# Altium schematic sheet Power.SchDoc
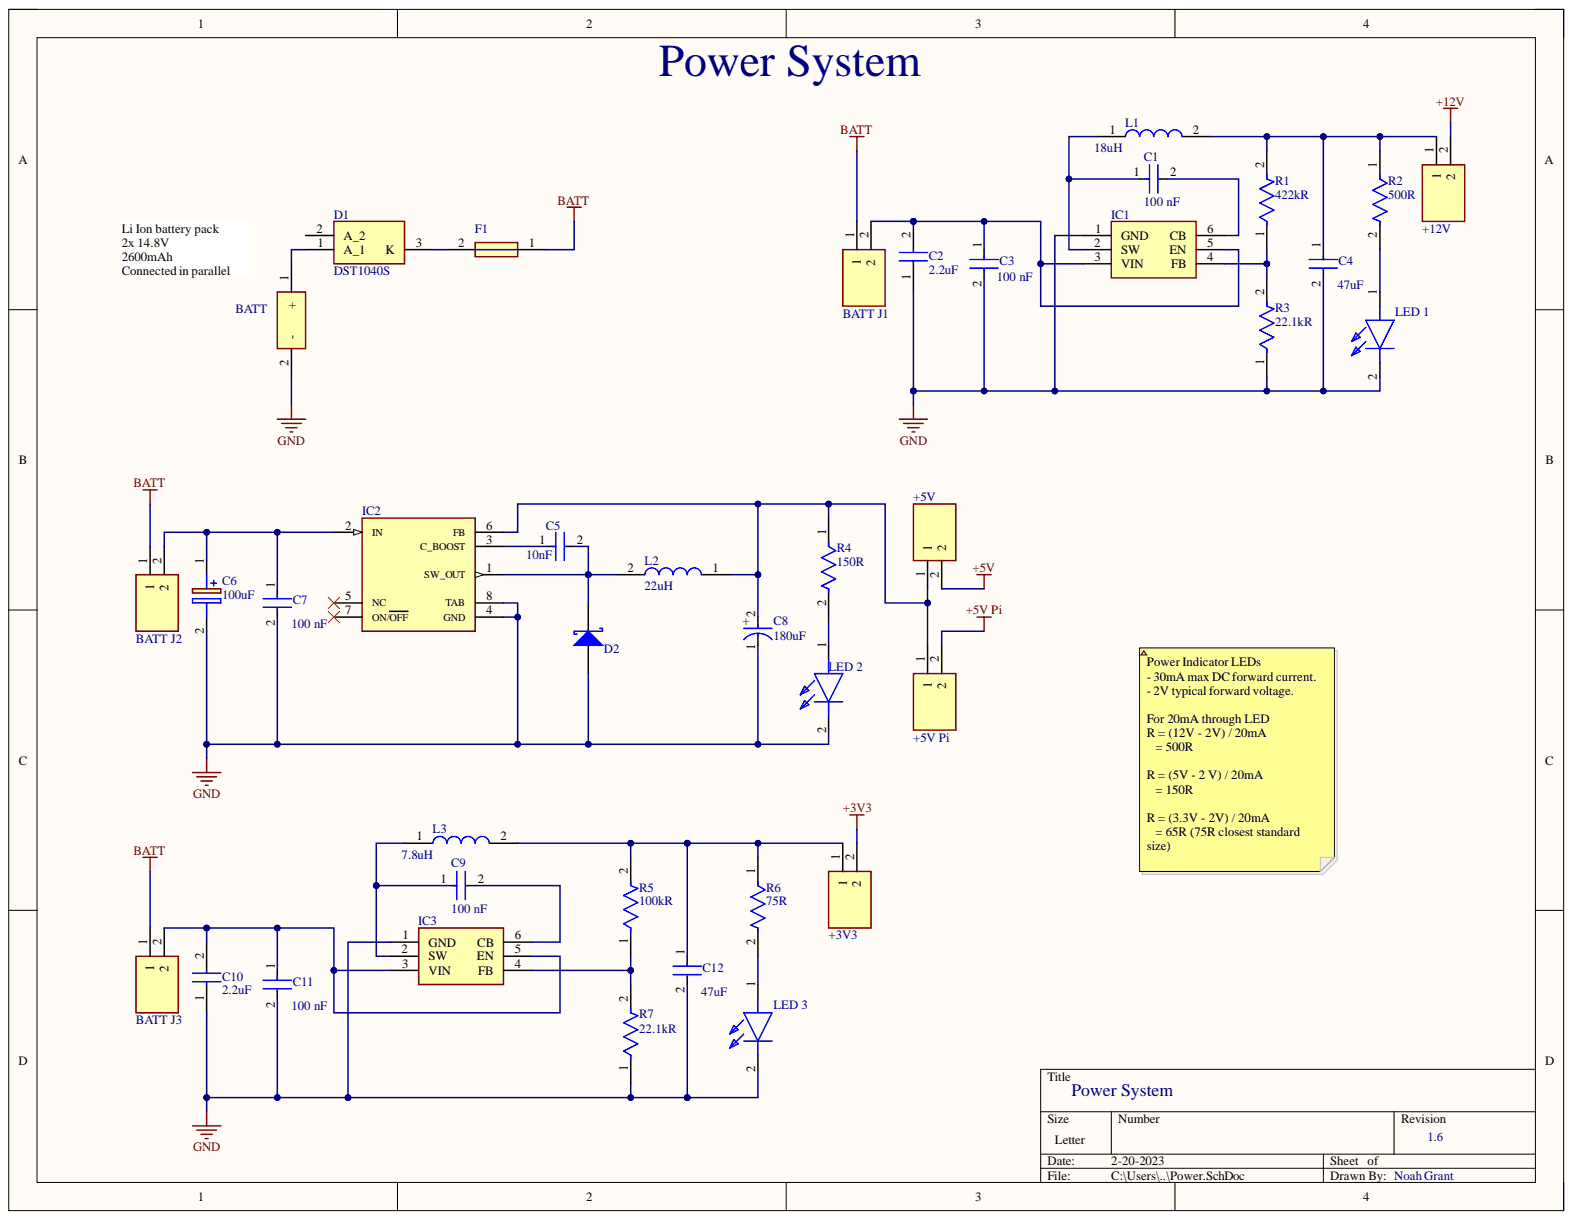
[diagram p0: Power.SchDoc]

## Parts
+3V3  0022284023  [HDRV2W87P0X254_1X2_508X249X863P]  (value not drawn)
+5V  0022284023  [HDRV2W87P0X254_1X2_508X249X863P]  (value not drawn)
+5V_Pi  0022284023  [HDRV2W87P0X254_1X2_508X249X863P]  (value not drawn)
+12V  0022284023  [HDRV2W87P0X254_1X2_508X249X863P]  (value not drawn)
BATT  1702473  [1702473]  (value not drawn)
BATT_J1  0022284023  [HDRV2W87P0X254_1X2_508X249X863P]  (value not drawn)
BATT_J2  0022284023  [HDRV2W87P0X254_1X2_508X249X863P]  (value not drawn)
BATT_J3  0022284023  [HDRV2W87P0X254_1X2_508X249X863P]  (value not drawn)
C1  value 100 nF  Capacitance: 100 nF  [FP-CC0603-DA-MFG]  (hidden comment: CC0603KPX7R9BB104)
C2  Capacitance: 2.2uF  [CAPC3216X180N]  (hidden comment: GRM31CR61H225KA88L)
C3  value 100 nF  Capacitance: 100 nF  [FP-CC0603-DA-MFG]  (hidden comment: CC0603KPX7R9BB104)
C4  Capacitance: 47uF  [FP-GRM32E-0_3-0_2-IPC_C]  (hidden comment: GRM32ER61C476KE15L)
C5  Capacitance: 10nF  [FP-CGA4J-IPC_B]  (hidden comment: CGA4C2C0G1H103J060AA)
C6  Capacitance: 100uF  [CAPAE1030X1050N]  (hidden comment: 50PFV100M10X10.5)
C7  value 100 nF  [FP-CC0603-DA-MFG]  (hidden comment: CC0603KPX7R9BB104)
C8  Capacitance: 180uF  [FP-OS-CON-SMT-C6-MFG]  (hidden comment: 16SVPF180M)
C9  value 100 nF  Capacitance: 100 nF  [FP-CC0603-DA-MFG]  (hidden comment: CC0603KPX7R9BB104)
C10  Capacitance: 2.2uF  [CAPC3216X180N]  (hidden comment: GRM31CR61H225KA88L)
C11  value 100 nF  Capacitance: 100 nF  [FP-CC0603-DA-MFG]  (hidden comment: CC0603KPX7R9BB104)
C12  Capacitance: 47uF  [FP-GRM32E-0_3-0_2-IPC_C]  (hidden comment: GRM32ER61C476KE15L)
D1  DST1040S  [DST1040SA]
D2  B560C-13-F  [DIOD-B5X0C-2_M]  (value not drawn)
F1  TR2_6125FF5-R  [FUSC8640X287N]  (value not drawn)
IC1  LMR51420XDDCR  [SOT95P280X110-6N]  (value not drawn)
IC2  LM2677SX-5.0/NOPB  [TO127P1435X464-8N]  (value not drawn)
IC3  LMR51420XDDCR  [SOT95P280X110-6N]  (value not drawn)
L1  Inductance: 18uH  [SRR1210]  (hidden comment: SRR1210-180M)
L2  Inductance: 22uH  [INDPM132125X500N]  (hidden comment: MPX1D1250L220)
L3  Inductance: 7.8uH  [WE-HCI_103023]  (hidden comment: 744325780)
LED_1  APL3015EC-F01  [APL3015ECF01]  (value not drawn)
LED_2  APL3015EC-F01  [APL3015ECF01]  (value not drawn)
LED_3  APL3015EC-F01  [APL3015ECF01]  (value not drawn)
R1  Resistance: 422kR  [FP-ERA6A-IPC_A]  (hidden comment: ERA-6AEB4223V)
R2  500R  [FP-TFCR0603-IPC_A]
R3  Resistance: 22.1kR  [FP-RNCP0805-IPC_C]  (hidden comment: RNCP0805FTD22K1)
R4  150R  [FP-MCT0603-IPC_C]
R5  Resistance: 100kR  [FP-RC0603-0_55-MFG]  (hidden comment: RC0603FR-07100KL)
R6  75R  [FP-RT0603-MFG]
R7  Resistance: 22.1kR  [FP-RNCP0805-IPC_C]  (hidden comment: RNCP0805FTD22K1)

## Nets
+3V3: +3V3.2
+5V: +5V.2
+5V Pi: +5V_Pi.2
+12V: +12V.2
BATT: BATT_J1.1 BATT_J2.1 BATT_J3.1 F1.1
GND: BATT.2 C2.1 C3.2 C4.2 C6.2 C7.2 C8.1 C10.1 C11.2 C12.2 D2.2 IC1.1(GND) IC2.4(GND) IC2.8(TAB) IC3.1(GND) LED_1.2 LED_2.2 LED_3.2 R3.1 R7.1
Net+3V3_1: +3V3.1 C12.1 L3.2 R5.2 R6.1
Net+5V Pi_1: +5V.1 +5V_Pi.1 C8.2 IC2.6(FB) L2.1 R4.1
Net+12V_1: +12V.1 C4.1 L1.2 R1.2 R2.1
NetBATT J1_2: BATT_J1.2 C2.2 C3.1 IC1.3(VIN) IC1.5(EN)
NetBATT J2_2: BATT_J2.2 C6.1 C7.1 IC2.2(IN)
NetBATT J3_2: BATT_J3.2 C10.2 C11.1 IC3.3(VIN) IC3.5(EN)
NetBATT_1: BATT.1 D1.1
NetC1_1: C1.1 IC1.2(SW) L1.1
NetC1_2: C1.2 IC1.6(CB)
NetC5_1: C5.1 IC2.3(C_BOOST)
NetC5_2: C5.2 D2.1 IC2.1(SW_OUT) L2.2
NetC9_1: C9.1 IC3.2(SW) L3.1
NetC9_2: C9.2 IC3.6(CB)
NetD1_3: D1.3 F1.2
NetIC1_4: IC1.4(FB) R1.1 R3.2
NetIC3_4: IC3.4(FB) R5.1 R7.2
NetLED 1_1: LED_1.1 R2.2
NetLED 2_1: LED_2.1 R4.2
NetLED 3_1: LED_3.1 R6.2

Not connected: D1 2; IC2 5(NC) 7(ON/OFF)

## Library data of the parts
+3V3 +5V +5V_Pi +12V BATT_J1-BATT_J3 = 0022284023: Connector; Manufacturer_Name: Molex; Arrow Part Number: 0022284023; Arrow Price/Stock: https://www.arrow.com/en/products/0022284023/molex; Component Kind: Standard; Datasheet Link: https://www.molex.com/pdm_docs/sd/022284023_sd.pdf; Height: 8.63mm; Manufacturer_Part_Number: 0022284023; Mouser Part Number: N/A; Mouser Price/Stock: https://www.mouser.co.uk/ProductDetail/Molex/0022284023?qs=PBcRNPEHKsr%2FPE%2F%252B8ije0g%3D%3D
BATT = 1702473: Connector; Manufacturer_Name: Phoenix Contact; Arrow Part Number: 1702473; Arrow Price/Stock: https://www.arrow.com/en/products/1702473/phoenix-contact?region=nac; Component Kind: Standard; Datasheet Link: http://www.phoenixcontact.com/de/produkte/1702473/pdf; Height: 5.22mm; Manufacturer_Part_Number: 1702473; Mouser Part Number: 651-1702473; Mouser Price/Stock: https://www.mouser.co.uk/ProductDetail/Phoenix-Contact/1702473?qs=i0qWf6NAoDu6Kp2LncOlag%3D%3D
C1 C3 C9 C11 = CMP-03422-000468-1: CAP CER 0.1UF 50V X7R 0603; Manufacturer: YAGEO; Material: Ceramic; Temperature Coefficient: 15%; Tolerance: 0.1; Automotive: No; Category: Cap; Component Kind: Standard; ComponentLink2Description: Datasheet; ComponentLink2URL: http://www.yageo.com/documents/recent/UPY-GPHC_X7R_6.3V-to-50V_18.pdf; Device Class L1: Passive Components; Device Class L2: Capacitors; Device Class L3: Ceramic Capacitors; Digikey Description: CAP CER 0.1UF 50V X7R 0603; Footprint Url: http://www.yageo.com/exep/pages/download/literatures/UPY-C_GEN_24.pdf; Height: 0.9mm; Lead Free: Yes; Library Name: Altium Content Vault; Manufacturer Part Number: CC0603KPX7R9BB104; Mouser Description: Multilayer Ceramic Capacitors MLCC - SMD/SMT 100nF 50V X7R 10%; Octopart: https://octopart.com/cc0603kpx7r9bb104-yageo-12885113; Package: CC0603-DA; RoHS: TRUE; Temperature: -55°C to +125°C; Temperature Characteristic: X7R; Temperature Range High: +125°C; Temperature Range Low: -55°C; Voltage: 50 V; Voltage Rating: 50 V
C2 C10 = GRM31CR61H225KA88L: Capacitor; Manufacturer_Name: Murata Electronics; Component Kind: Standard; Datasheet Link: https://datasheet.datasheetarchive.com/originals/distributors/Datasheets_SAMA/ec4f1577dddcc7a13ddad897a28002d3.pdf; Height: 1.8mm; Manufacturer_Part_Number: GRM31CR61H225KA88L; Mouser Part Number: 81-GRM31CR61H225KA8L; Mouser Price/Stock: https://www.mouser.co.uk/ProductDetail/Murata-Electronics/GRM31CR61H225KA88L?qs=PNuoBeNXpiW6XsFe%2FHBnHw%3D%3D
C4 C12 = CMP-2000-06362-2: CAP CER 47UF 16V X5R 1210; Material: Ceramic; Tolerance: 10%; Case Code (Imperial): 1210; Case Code (Metric): 3225; Case/Package: 1210; Component Kind: Standard; Depth: 2.5mm; Dielectric: X5R; Height: 2.5mm; Lead Free: Lead Free; Length: 3.2mm; Library Name: Altium Content Vault; Max Operating Temperature: 85°C; Min Operating Temperature: -55°C; Mount: Surface Mount; Number of Pins: 2; Package Quantity: 1; Packaging: Cut Tape; Radiation Hardening: No; REACH SVHC: No SVHC; RoHS Compliant: Yes; Termination: SMD/SMT; Thickness: 0.106inch; Voltage: 16V; Voltage Rating: 16V; Voltage Rating (DC): 16V; Width: 0.098inch
C5 = CMP-08246-000595-1: Cap Ceramic 10nF 50V C0G 5% Pad SMD 0805 +125°C Automotive T/R; Case Code (Imperial): 0805; Case Code (Metric): 2012; Case/Package: 0805; Component Kind: Standard; Composition: Ceramic; Depth: 1.25mm; Dielectric: C0G; Height: 0.6mm; Lead Free: Lead Free; Length: 2mm; Library Name: Altium Content Vault; Max Operating Temperature: 125°C; Min Operating Temperature: -55°C; Mount: Surface Mount; Package Quantity: 1; Packaging: Tape and Reel; Radiation Hardening: No; Ratings: AEC-Q200; REACH SVHC: No SVHC; Termination: SMD/SMT; Thickness: 0.028inch; Tolerance: 5%; Voltage Rating: 50V; Voltage Rating (DC): 50V; Weight: 0.000194oz; Width: 0.049inch
C6 = 50PFV100M10X10.5: Capacitor Polarised; Manufacturer_Name: Rubycon; Arrow Part Number: 50PFV100M10X10.5; Arrow Price/Stock: https://www.arrow.com/en/products/50pfv100m10x10.5/rubycon?region=nac; Component Kind: Standard; Datasheet Link: https://www.mouser.jp/datasheet/2/977/e_PFV-1600672.pdf; Height: 10.5mm; Manufacturer_Part_Number: 50PFV100M10X10.5; Mouser Part Number: 232-50PFV100M10X10.5; Mouser Price/Stock: https://www.mouser.co.uk/ProductDetail/Rubycon/50PFV100M10X10.5?qs=T3oQrply3y%252BvhjwV%252B%252Bv4tQ%3D%3D
C7 = CMP-03422-000468-1: CAP CER 0.1UF 50V X7R 0603; Manufacturer: YAGEO; Capacitance: 100 nF; Material: Ceramic; Temperature Coefficient: 15%; Tolerance: 0.1; Value: 100 nF; Automotive: No; Category: Cap; Component Kind: Standard; ComponentLink2Description: Datasheet; ComponentLink2URL: http://www.yageo.com/documents/recent/UPY-GPHC_X7R_6.3V-to-50V_18.pdf; Device Class L1: Passive Components; Device Class L2: Capacitors; Device Class L3: Ceramic Capacitors; Digikey Description: CAP CER 0.1UF 50V X7R 0603; Footprint Url: http://www.yageo.com/exep/pages/download/literatures/UPY-C_GEN_24.pdf; Height: 0.9mm; Lead Free: Yes; Library Name: Altium Content Vault; Manufacturer Part Number: CC0603KPX7R9BB104; Mouser Description: Multilayer Ceramic Capacitors MLCC - SMD/SMT 100nF 50V X7R 10%; Octopart: https://octopart.com/cc0603kpx7r9bb104-yageo-12885113; Package: CC0603-DA; RoHS: TRUE; Temperature: -55°C to +125°C; Temperature Characteristic: X7R; Temperature Range High: +125°C; Temperature Range Low: -55°C; Voltage: 50 V; Voltage Rating: 50 V
C8 = CMP-05428-001090-1: Conductive Polymer Aluminum Solid Capacitors (OS-CON) 180uF ±20% 16V; Dissipation Factor: 12%; ESR (Equivalent Series Resistance): 22mR; Leakage Current: 576uA; Life (Hours): 5000Hour; Polarity: Polar; Ripple Current: 3.3A; Case/Package: Radial; Component Kind: Standard; Construction: Cylindrical; Diameter: 6.3mm; Height: 5.9mm; Height - Seated (Max): 0.236inch; Lead Free: Lead Free; Length: 5.9mm; Library Name: Altium Content Vault; Max Operating Temperature: 105°C; Min Operating Temperature: -55°C; Mount: Surface Mount; Number of Pins: 6; Package Quantity: 1; Packaging: Tape and Reel; Radiation Hardening: No; REACH SVHC: No SVHC; Ripple Current (AC): 3.3A; RoHS Compliant: Yes; Series: OS-CON™, SVPF; Termination: SMD/SMT; Tolerance: 20%; Voltage: 16V; Voltage Rating: 16V; Voltage Rating (DC): 16V
D1 = DST1040S: Schottky Diode; Manufacturer_Name: LITTELFUSE; Arrow Part Number: DST1040S; Arrow Price/Stock: https://www.arrow.com/en/products/dst1040s/littelfuse; Component Kind: Standard; Datasheet Link: https://m.littelfuse.com/~/media/electronics/datasheets/power_semiconductors/littelfuse_power_semiconductor_schottky_diode_dst1040s_datasheet.pdf.pdf; Height: 1.25mm; Manufacturer_Part_Number: DST1040S; Mouser Part Number: 576-DST1040S; Mouser Price/Stock: https://www.mouser.co.uk/ProductDetail/Littelfuse/DST1040S?qs=%252BC9Bk%2FBEkFwEQIZlBedqnA%3D%3D
D2 = CMP-2000-05098-2: Schottky Barrier Rectifier, 5 A, 60 V, -55 to 125 degC, 2-Pin SMD, RoHS, Tape and Reel; Brand: DiodesIncorporated; Configuration: Single; If - Forward Current: 5A; Ifsm - Forward Surge Current: 100A; Ir - Reverse Current: 500uA; Unit Weight: 0.007408oz; Vf - Forward Voltage: 0.7V; Vrrm - Repetitive Reverse Voltage: 60V; Component Kind: Standard; Datasheet URL: https://datasheet.ciiva.com/27052/1538961-27052355.pdf; Datasheet Version: Rev. 7-2, 06/2007; Factory Pack Quantity: 3000; Height: 2.5mm; Length: 7.11mm; Library Name: Altium Content Vault; Manufacturer: DiodesIncorporated; Manufacturer URL: http://www.diodes.com/; Maximum Operating Temperature: +125C; Minimum Operating Temperature: -55C; Mounting Style: SMD/SMT; Operating Temperature Range: -55Cto+125C; Package / Case: SMC; Packaging: Reel; Product: SchottkyDiodes; Product Category: SchottkyDiodes&Rectifiers; RoHS: True; Series: B560; Technology: Si; Termination Style: SMD/SMT; Type: SchottkyBarrierRectifier; Width: 6.22mm
F1 = TR2_6125FF5-R: Fuse; Manufacturer_Name: Eaton; Manufacturer_Part_Number: TR2/6125FF5-R; Component Kind: Standard; Datasheet Link: https://ms.componentsearchengine.com/Datasheets/2/TR2_6125FF5-R.pdf; Height: 2.87mm
IC1 IC3 = LMR51420XDDCR: Integrated Circuit; Manufacturer_Name: Texas Instruments; Component Kind: Standard; Datasheet Link: https://www.ti.com/lit/ds/symlink/lmr51420.pdf?ts=1663231243064; Height: 1.1mm; Manufacturer_Part_Number: LMR51420XDDCR; Mouser Part Number: 595-LMR51420XDDCR; Mouser Price/Stock: https://www.mouser.co.uk/ProductDetail/Texas-Instruments/LMR51420XDDCR?qs=vvQtp7zwQdN6fBqQU4azxw%3D%3D
IC2 = LM2677S-ADJ_NOPB: Integrated Circuit; Manufacturer_Name: Texas Instruments; Manufacturer_Part_Number: LM2677S-ADJ/NOPB; Arrow Part Number: LM2677S-ADJ/NOPB; Arrow Price/Stock: https://www.arrow.com/en/products/lm2677s-adjnopb/texas-instruments; Component Kind: Standard; Datasheet Link: http://www.ti.com/lit/ds/symlink/lm2677.pdf; Height: 4.64mm; Mouser Part Number: 926-LM2677S-ADJ/NOPB; Mouser Price/Stock: https://www.mouser.co.uk/ProductDetail/Texas-Instruments/LM2677S-ADJ-NOPB?qs=X1J7HmVL2ZFcqPykAfO2og%3D%3D
L1 = SRR1210-180M: Inductor; Manufacturer_Name: Bourns; Arrow Part Number: SRR1210-180M; Arrow Price/Stock: https://www.arrow.com/en/products/srr1210-180m/bourns; Component Kind: Standard; Datasheet Link: https://www.bourns.com/pdfs/SRR1210.pdf; Manufacturer_Part_Number: SRR1210-180M; Mouser Part Number: 652-SRR1210-180M; Mouser Price/Stock: https://www.mouser.co.uk/ProductDetail/Bourns/SRR1210-180M?qs=4vvWAaIu%2Fq5trcUyNP0dXQ%3D%3D
L2 = MPX1D1250L220: Inductor; Manufacturer_Name: KEMET; Component Kind: Standard; Datasheet Link: https://content.kemet.com/datasheets/KEM_L9012_MPX.pdf; Height: 5mm; Manufacturer_Part_Number: MPX1D1250L220
L3 = 744325780: Inductor; Manufacturer_Name: Wurth Elektronik; Component Kind: Standard; Manufacturer_Part_Number: 744325780; Mouser Part Number: 710-744325780; Mouser Price/Stock: https://www.mouser.co.uk/ProductDetail/Wurth-Elektronik/744325780?qs=VxkwJfKV0FQ2%2FeCS8beGcw%3D%3D
LED_1-LED_3 = APL3015EC-F01: LED; Manufacturer_Name: Kingbright; Component Kind: Standard; Datasheet Link: https://componentsearchengine.com/Datasheets/1/APL3015EC-F01.pdf; Manufacturer_Part_Number: APL3015EC-F01; Mouser Part Number: 604-APL3015EC-F01; Mouser Price/Stock: https://www.mouser.co.uk/ProductDetail/Kingbright/APL3015EC-F01?qs=VdjlWU%2FzoOF91deOgS6C3g%3D%3D
R1 = CMP-2001-00824-3: RES 422K OHM 0.1% 1/8W 0805; Max Power Dissipation: 125mW; Temperature Coefficient: 25ppm/°C; Voltage Rating: 100V; Case Code (Imperial): 0805; Case Code (Metric): 2012; Case/Package: 0805; Component Kind: Standard; Construction: Rectangular; Contact Plating: Tin; Depth: 1.25mm; Features: Automotive AEC-Q200; Height: 0.5mm; Lead Free: Lead Free; Length: 2mm; Library Name: Altium Content Vault; Max Operating Temperature: 155°C; Military Standard: Not; Min Operating Temperature: -55°C; Mount: Surface Mount; Number of Pins: 2; Number of Terminations: 2; Package Quantity: 5000; Packaging: Tape and Reel; Power Rating: 125mW; Radiation Hardening: No; Ratings: AEC-Q200; Reach SVHC Compliant: Yes; Resistance Tolerance: 0.1%; Resistor Type: Thin Film; Series: ERA-6A; Technology: METAL FILM; Termination: Solder; Tolerance: 0.1%; Voltage Rating (DC): 100V; Width: 1.25mm
R2 = CMP-250906-063952-1: RES Thin Film,  500Ω, 0.1%, 0.1W, 25ppm/°C, 0603; Component Kind: Standard; Library Name: Altium Content Vault; RoHS Compliant: Yes
R3 R7 = CMP-26527-000518-1: 22.1kΩ ±1% 0.25W 0805 High Power Anti-Sulfur Thin Film Chip Resistor; Max Power Dissipation: 250mW; Temperature Coefficient: 100ppm/°C; Case Code (Imperial): 0805; Case Code (Metric): 2012; Case/Package: 0805; Component Kind: Standard; Features: Moisture Resistant; Height: 0.024inch; Length: 0.079inch; Library Name: Altium Content Vault; Max Operating Temperature: 155°C; Min Operating Temperature: -55°C; Mount: Surface Mount; Number of Terminations: 2; Packaging: Tape and Reel; Resistor Type: Thin Film; RoHS Compliant: Yes; Series: RNCP; Tolerance: 1%; Width: 0.049inch
R4 = CMP-02407-011712-1: RES SMD 150 OHM 1% 1/8W 0603; Max Power Dissipation: 150mW; Power Rating: 125mW; Resistance Tolerance: 0.5%; Temperature Coefficient: 25ppm/°C; Voltage Rating: 75V; Case Code (Imperial): 0603; Case Code (Metric): 1608; Case/Package: 0603; Component Kind: Standard; Construction: Rectangular; Depth: 0.85mm; Features: Automotive AEC-Q200; Height: 0.022inch; Length: 0.061inch; Library Name: Altium Content Vault; Max Operating Temperature: 175°C; Military Standard: Not; Min Operating Temperature: -55°C; Number of Pins: 2; Number of Terminations: 2; Package Quantity: 1; Packaging: Tape and Reel; REACH SVHC: No SVHC; Resistance: 150R; Resistor Type: Thin Film; RoHS Compliant: Yes; Series: MCT 0603 - Professional; Termination: SMD/SMT; Tolerance: 0.5%; Voltage Rating (DC): 75V; Width: 0.8mm
R5 = CMP-2100-03662-2: RES SMD 100K OHM 1% 1/10W 0603; Max Power Dissipation: 100mW; Temperature Coefficient: 100ppm/°C; Voltage Rating: 50V; Working Voltage: 75V; Case Code (Imperial): 0603; Case Code (Metric): 1608; Case/Package: 0603; Component Kind: Standard; Construction: Rectangular; Depth: 0.8mm; Features: Moisture Resistant; Height: 0.45mm; Lead Free: Lead Free; Length: 1.6mm; Library Name: Altium Content Vault; Max Operating Temperature: 155°C; Military Standard: Not; Min Operating Temperature: -55°C; Number of Pins: 2; Number of Terminations: 2; Packaging: Tape and Reel; Power Rating: 100mW; REACH SVHC: No SVHC; Resistance Tolerance: 1%; Resistor Type: Thick Film; RoHS Compliant: Yes; Series: RC; Technology: THICK FILM; Termination: SMD/SMT; Tolerance: 1%; Voltage Rating (DC): 50V; Width: 0.8mm
R6 = CMP-2000-04797-2: RES SMD 75 OHM 1% 1/10W 0603; Manufacturer: Yageo; Manufacturer Part Number: RT0603FRE0775RL; Power Rating: 0.1W; Resistance: 75Ω; Temperature Coefficient: 50 ppm/°C; Tolerance: 0.01; Value: 75Ω; Voltage Rating: 75V; Automotive: No; Category: Res; Component Kind: Standard; ComponentLink2Description: Datasheet; ComponentLink2URL: http://www.yageo.com/documents/recent/PYu-RT_1-to-0.01_RoHS_L_9.pdf; Composition: Thin Film; Device Class L1: Passive Components; Device Class L2: Resistors; Device Class L3: Chip SMD Resistors; Digikey Description: RES SMD 75 OHM 1% 1/10W 0603; Footprint Url: http://www.yageo.com/exep/pages/download/literatures/PYu-R_Mount_10.pdf; Height: 0.55mm; Ipc Land Pattern Name: RESC16080X45; Lead Free: Yes; Library Name: Altium Content Vault; Mouser Description: Res Thin Film 0603 75 Ohm 1% 1/10W ±50ppm/°C Molded SMD SMD Paper T/R; Octopart: https://octopart.com/rt0603fre0775rl-yageo-1163751; Package: RT0603; RoHS: TRUE; Series: RT; Temperature: -55°C to +155°C; Temperature Range High: +155°C; Temperature Range Low: -55°C
